# Altium schematic sheet W6100-io_V100.SchDoc
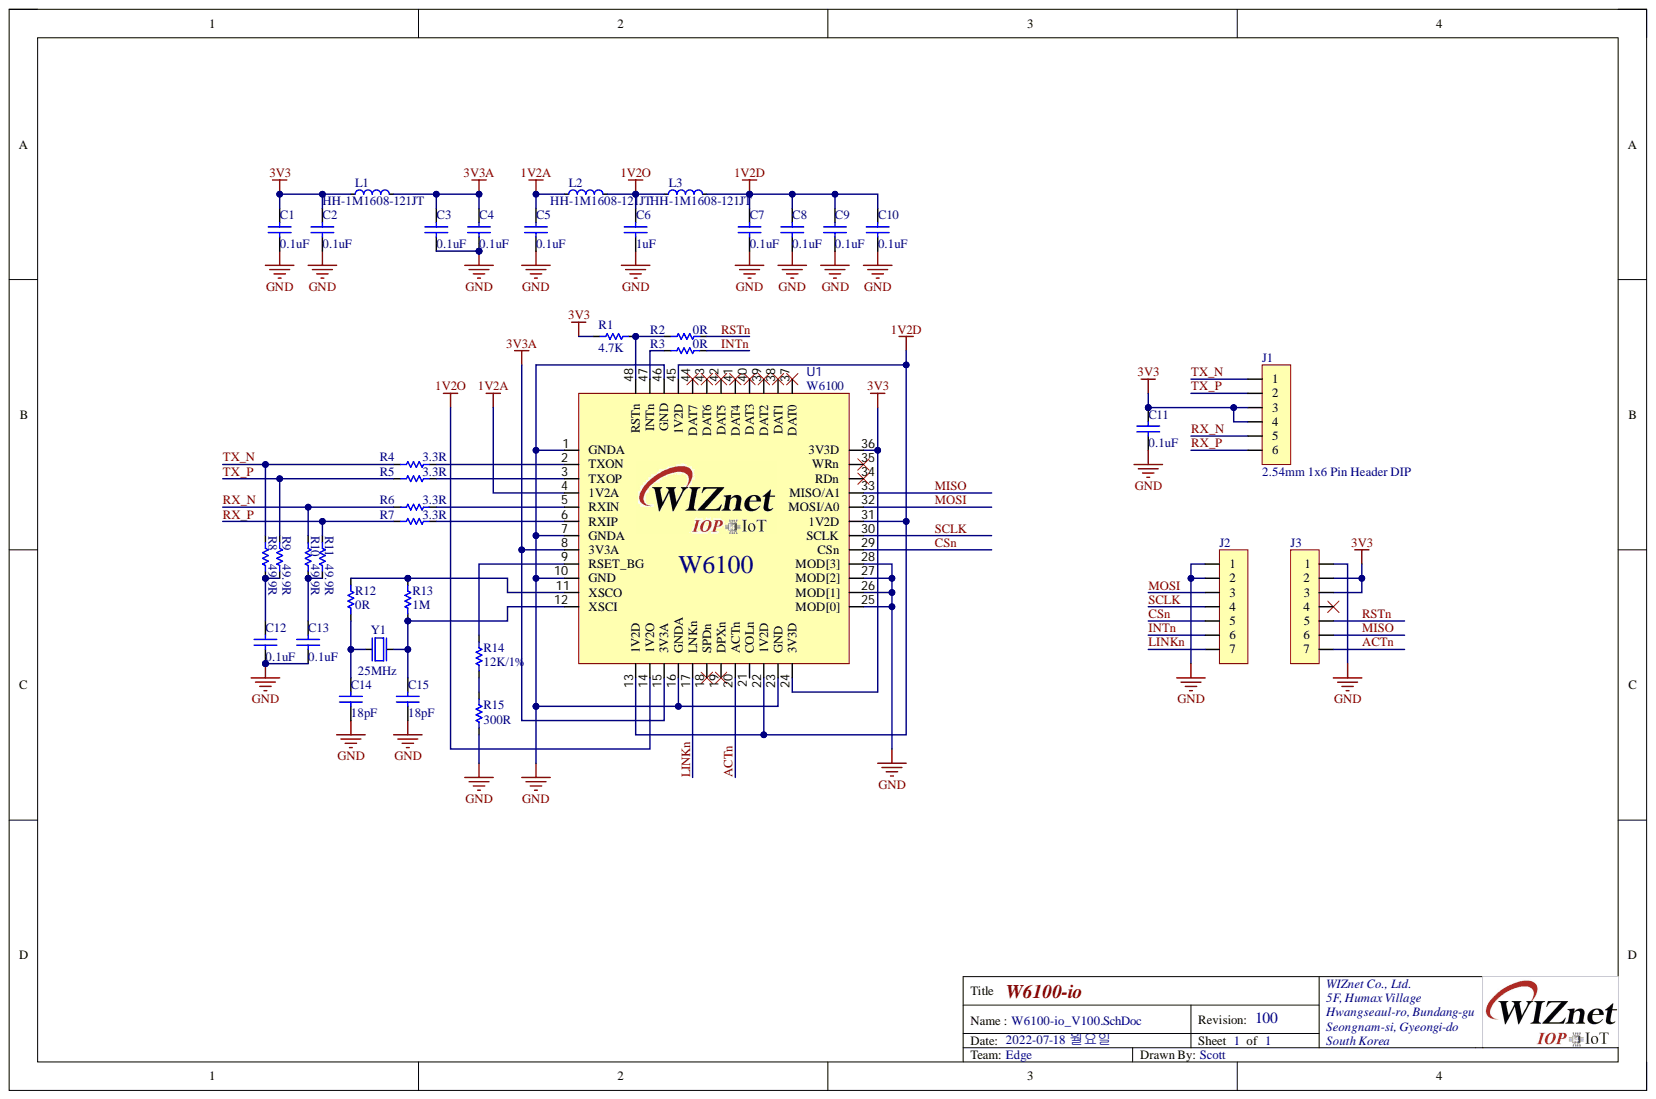
[diagram p0: W6100-io_V100.SchDoc]

## Parts
C1  0.1uF  [CAP-0402]
C2  0.1uF  [CAP-0402]
C3  0.1uF  [CAP-0402]
C4  0.1uF  [CAP-0402]
C5  0.1uF  [CAP-0402]
C6  1uF  [CAP-0402]
C7  0.1uF  [CAP-0402]
C8  0.1uF  [CAP-0402]
C9  0.1uF  [CAP-0402]
C10  0.1uF  [CAP-0402]
C11  0.1uF  [CAP-0402]
C12  0.1uF  [CAP-0402]
C13  0.1uF  [CAP-0402]
C14  18pF  [CAP-0402]
C15  18pF  [CAP-0402]
J1  2.54mm 1x6 Pin Header DIP  [IDC-1X6(Wi510io)]
J2  2.54mm 1x7 Pin Header DIP  [IDC-1X7(Wi510io)]  (value not drawn)
J3  2.54mm 1x7 Pin Header DIP  [IDC-1X7(Wi510io)]  (value not drawn)
L1  HH-1M1608-121JT  [IND-0603]
L2  HH-1M1608-121JT  [IND-0603]
L3  HH-1M1608-121JT  [IND-0603]
R1  4.7K  [RES-0402]
R2  0R  [RES-0402]
R3  0R  [RES-0402]
R4  3.3R  [RES-0402]
R5  3.3R  [RES-0402]
R6  3.3R  [RES-0402]
R7  3.3R  [RES-0402]
R8  49.9R  [RES-0402]
R9  49.9R  [RES-0402]
R10  49.9R  [RES-0402]
R11  49.9R  [RES-0402]
R12  0R  [RES-0402]
R13  1M  [RES-0402]
R14  12K/1%  [RES-0402]
R15  300R  [RES-0402]
U1  W6100  [W6100_LQFP48(0.5/9.0)]
Y1  25MHz  [OSC-82J0626]

## Nets
1V2A: C5.2 L2.1 U1.4(1V2A)
1V2D: C7.2 C8.2 C9.2 C10.2 L3.2 U1.13(1V2D) U1.22(1V2D) U1.31(1V2D) U1.45(1V2D)
1V2O: C6.2 L2.2 L3.1 U1.14(1V2O)
3V3: C1.2 C2.2 C11.2 J1.3 J1.4 J3.2 J3.3 L1.1 R1.1 U1.24(3V3D) U1.36(3V3D)
3V3A: C3.2 C4.2 L1.2 U1.8(3V3A) U1.15(3V3A)
GND: C1.1 C2.1 C3.1 C4.1 C5.1 C6.1 C7.1 C8.1 C9.1 C10.1 C11.1 C12.1 C13.1 C14.1 C15.1 J2.1 J2.2 J3.1 R15.2 U1.1(GNDA) U1.7(GNDA) U1.10(GND) U1.16(GNDA) U1.23(GND) U1.25(MOD[0]) U1.26(MOD[1]) U1.27(MOD[2]) U1.28(MOD[3]) U1.46(GND) Y1.2 Y1.4
ACTn: J3.7 U1.20(ACTn)
CSn: J2.5 U1.29(CSn)
INTn: J2.6 R3.2
LINKn: J2.7 U1.17(LNKn)
MISO: J3.6 U1.33(MISO/A1)
MOSI: J2.3 U1.32(MOSI/A0)
RSTn: J3.5 R2.2
RX_N: J1.5 R6.1 R10.2
RX_P: J1.6 R7.1 R11.2
SCLK: J2.4 U1.30(SCLK)
TX_N: J1.1 R4.1 R8.2
TX_P: J1.2 R5.1 R9.2
NetC12_2: C12.2 R8.1 R9.1
NetC13_2: C13.2 R10.1 R11.1
NetC14_2: C14.2 R12.1 Y1.1
NetC15_2: C15.2 R13.1 U1.12(XSCI) Y1.3
NetR1_2: R1.2 R2.1 U1.48(RSTn)
NetR3_1: R3.1 U1.47(INTn)
NetR4_2: R4.2 U1.2(TXON)
NetR5_2: R5.2 U1.3(TXOP)
NetR6_2: R6.2 U1.5(RXIN)
NetR7_2: R7.2 U1.6(RXIP)
NetR12_2: R12.2 R13.2 U1.11(XSCO)
NetR14_1: R14.1 U1.9(RSET_BG)
NetR14_2: R14.2 R15.1

Not connected: J3 4; U1 18(SPDn) 19(DPXn) 21(COLn) 34(RDn) 35(WRn) 37(DAT0) 38(DAT1) 39(DAT2) 40(DAT3) 41(DAT4) 42(DAT5) 43(DAT6) 44(DAT7)

## Library data of the parts
C1-C15 = CAP-0402: Ceramic CAP 0402(1005); Component Kind: Standard; Library Name: Scott_lib.IntLib
L1-L3 = IND-0603: Inductor 0603(1608); Component Kind: Standard; Library Name: Scott_lib.IntLib
R1-R15 = RES-0402: Component Kind: Standard; Description: RES-0402; Library Name: Scott_lib.IntLib
U1 = W6100: WIZnet Hardwired TCP/IP Chip; Component Kind: Standard; Library Name: Scott_lib.IntLib
Y1 = OSC-82J0626-25MHz: X-tal 25MHz,3.2x2.5 SMD, CL=18pF; Component Kind: Standard; Library Name: Scott_lib.IntLib
J1 = 2.54mm 1x6 Pin Header DIP: Component Kind: Standard; Description: 2.54mm 1x6 Pin Header DIP; Library Name: Scott_lib.IntLib
J2 J3 = 2.54mm 1x7 Pin Header DIP: Component Kind: Standard; Description: 2.54mm 1x7 Pin Header DIP; Library Name: Scott_lib.IntLib
